# Altium schematic sheet LFI Power Board Sheet1.SchDoc("LFI Power Board Sheet1")
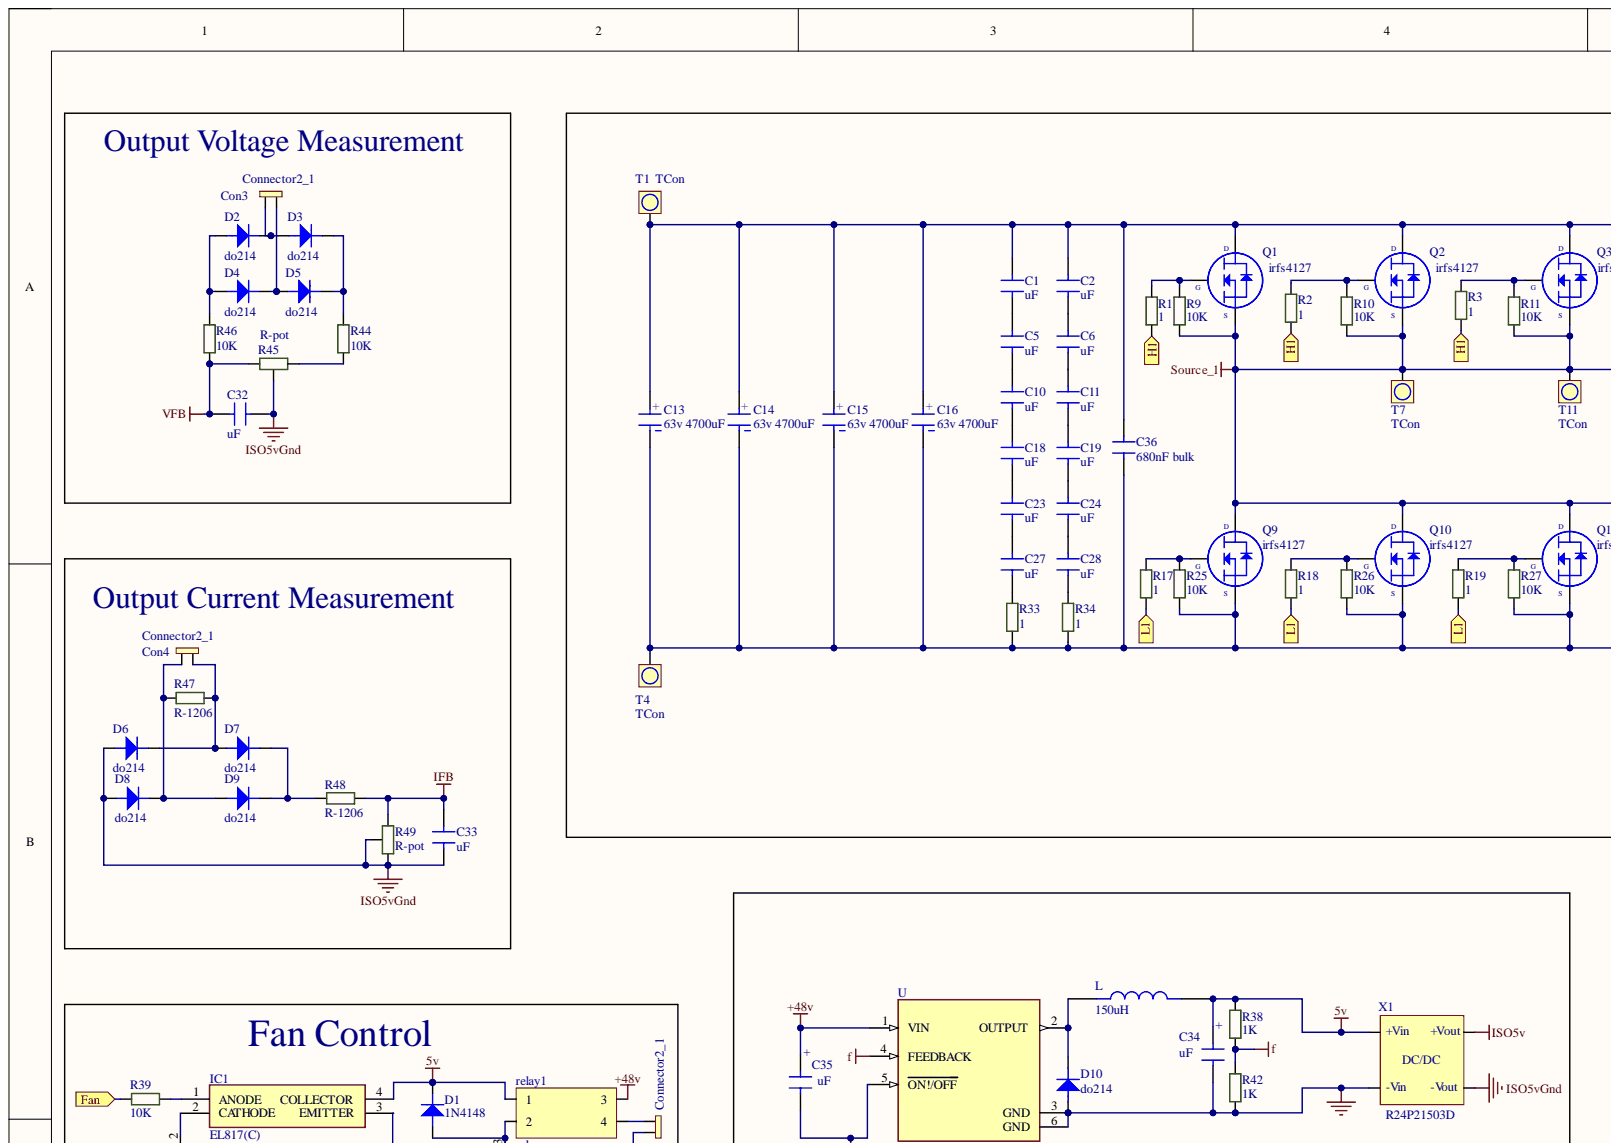
[diagram p0: LFI Power Board Sheet1.SchDoc, top left]
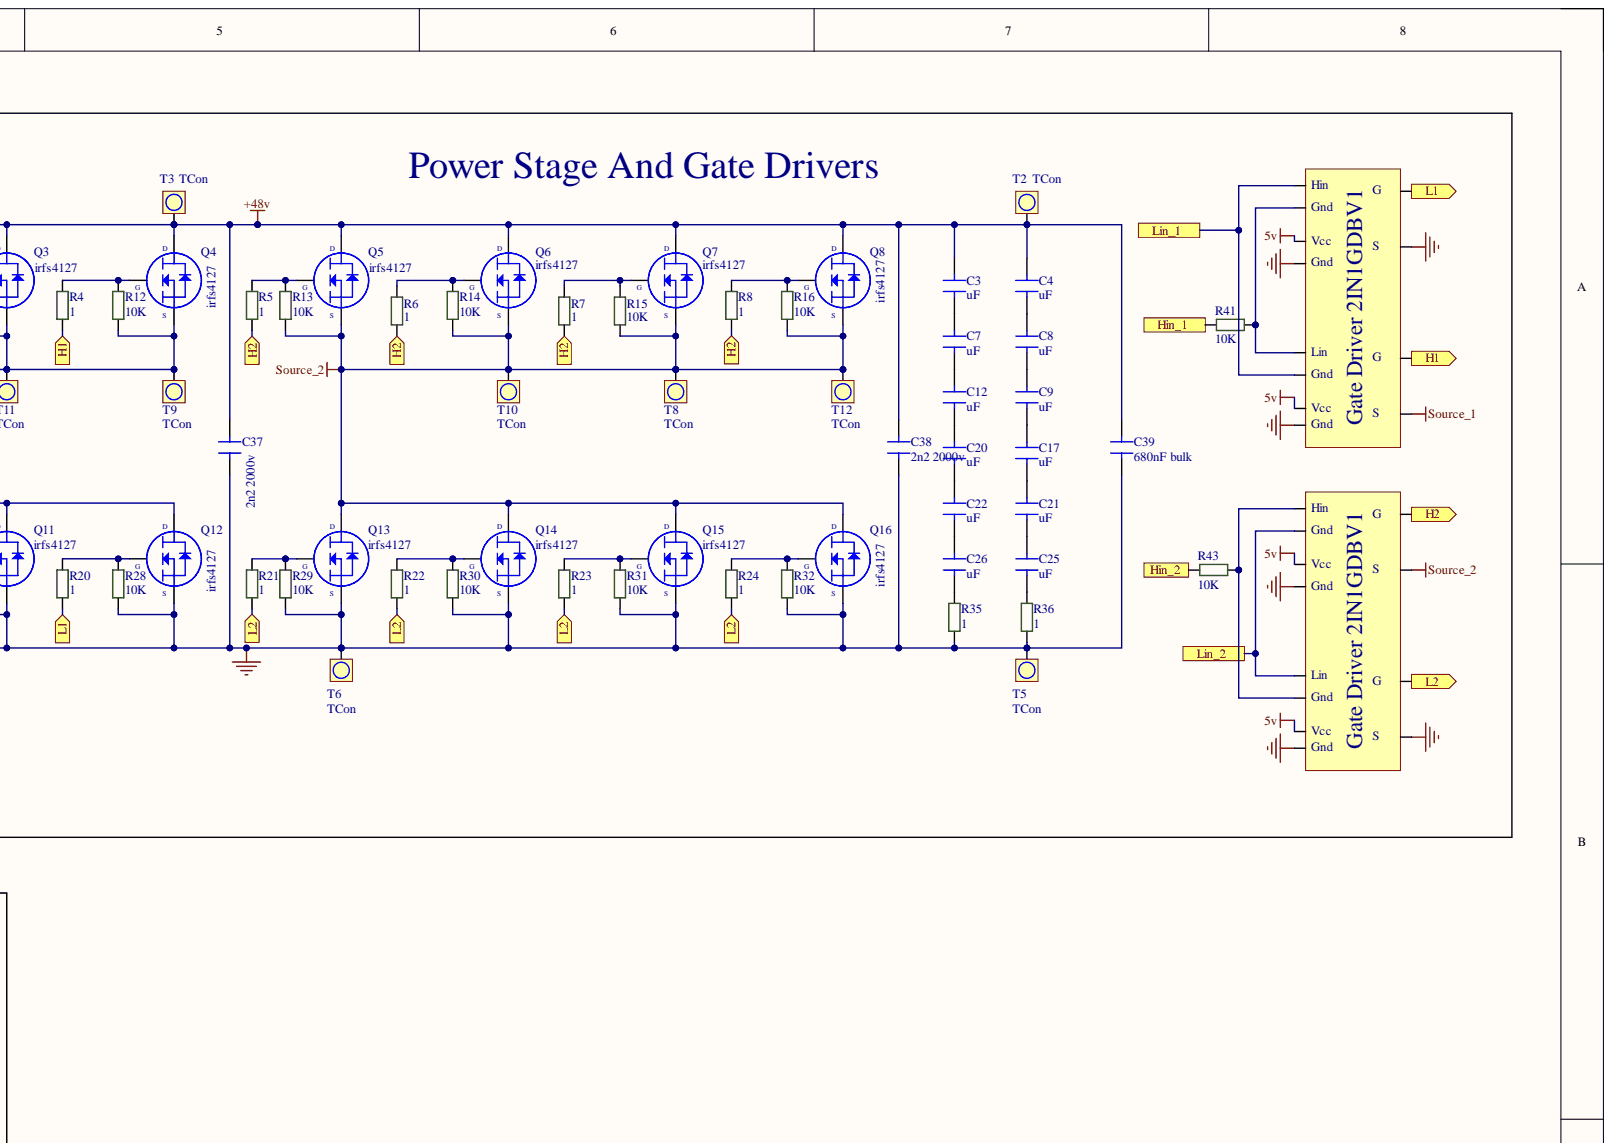
[diagram p1: LFI Power Board Sheet1.SchDoc, top right]
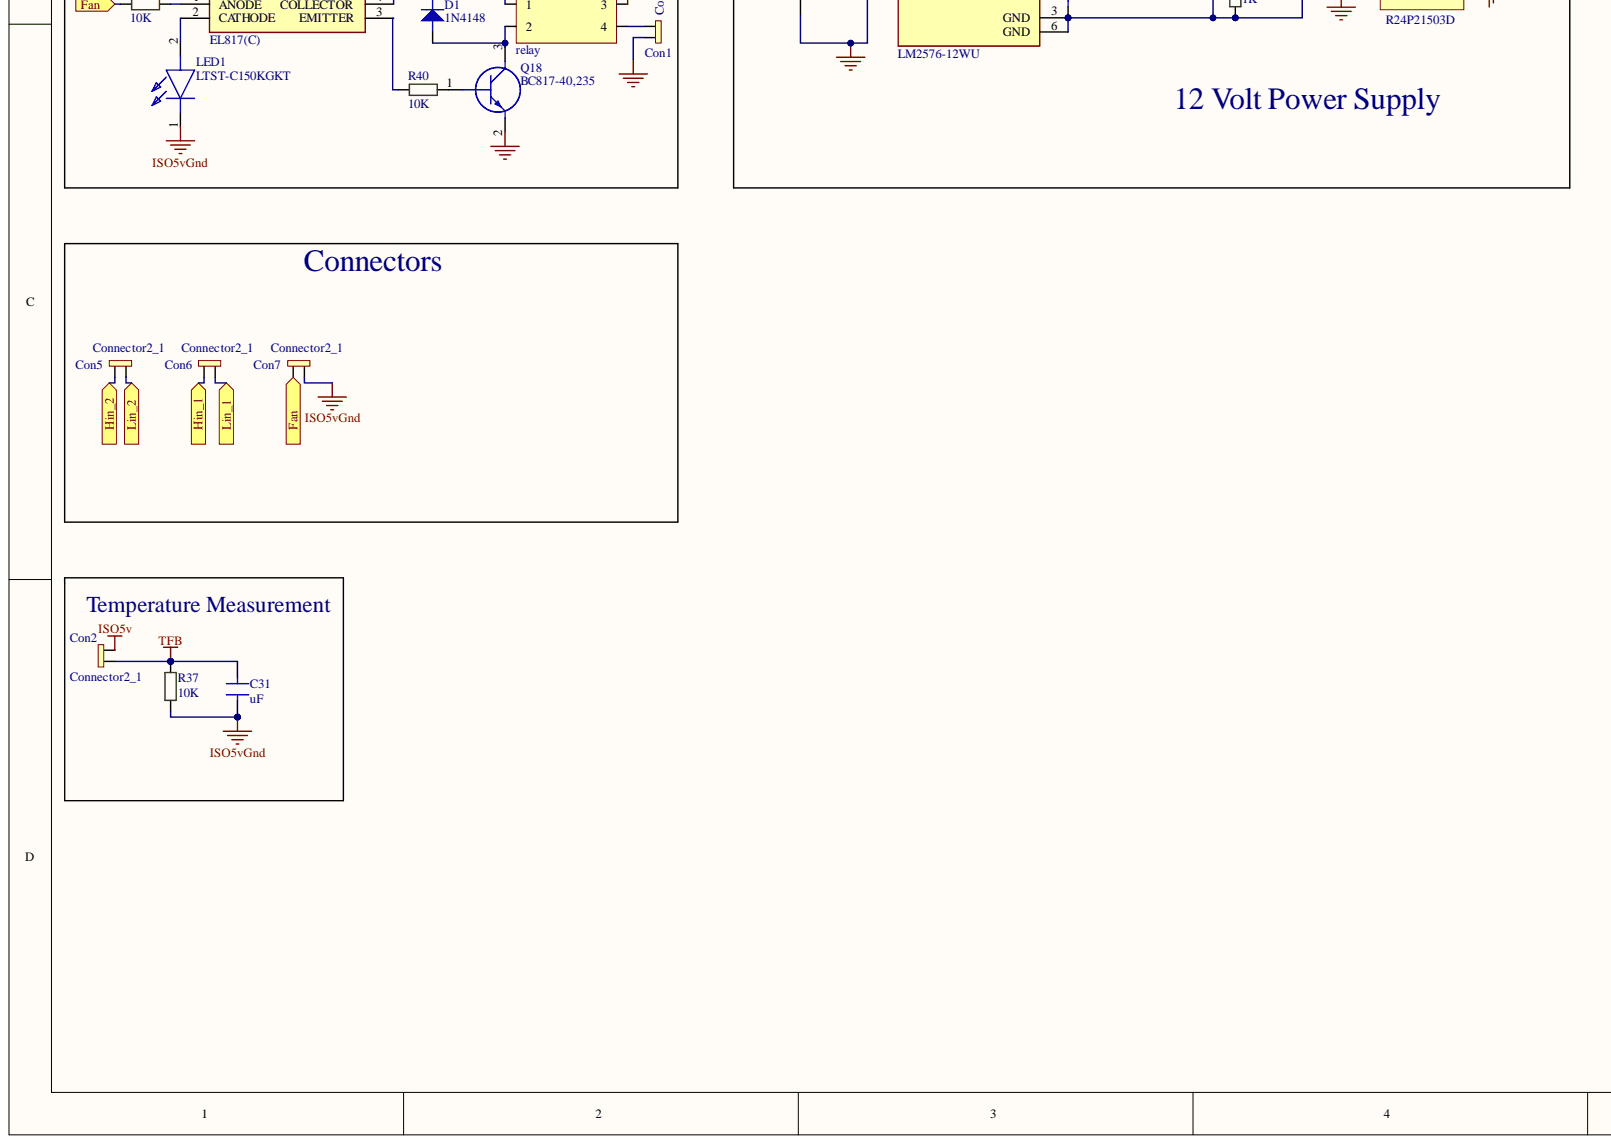
[diagram p2: LFI Power Board Sheet1.SchDoc, bottom left]
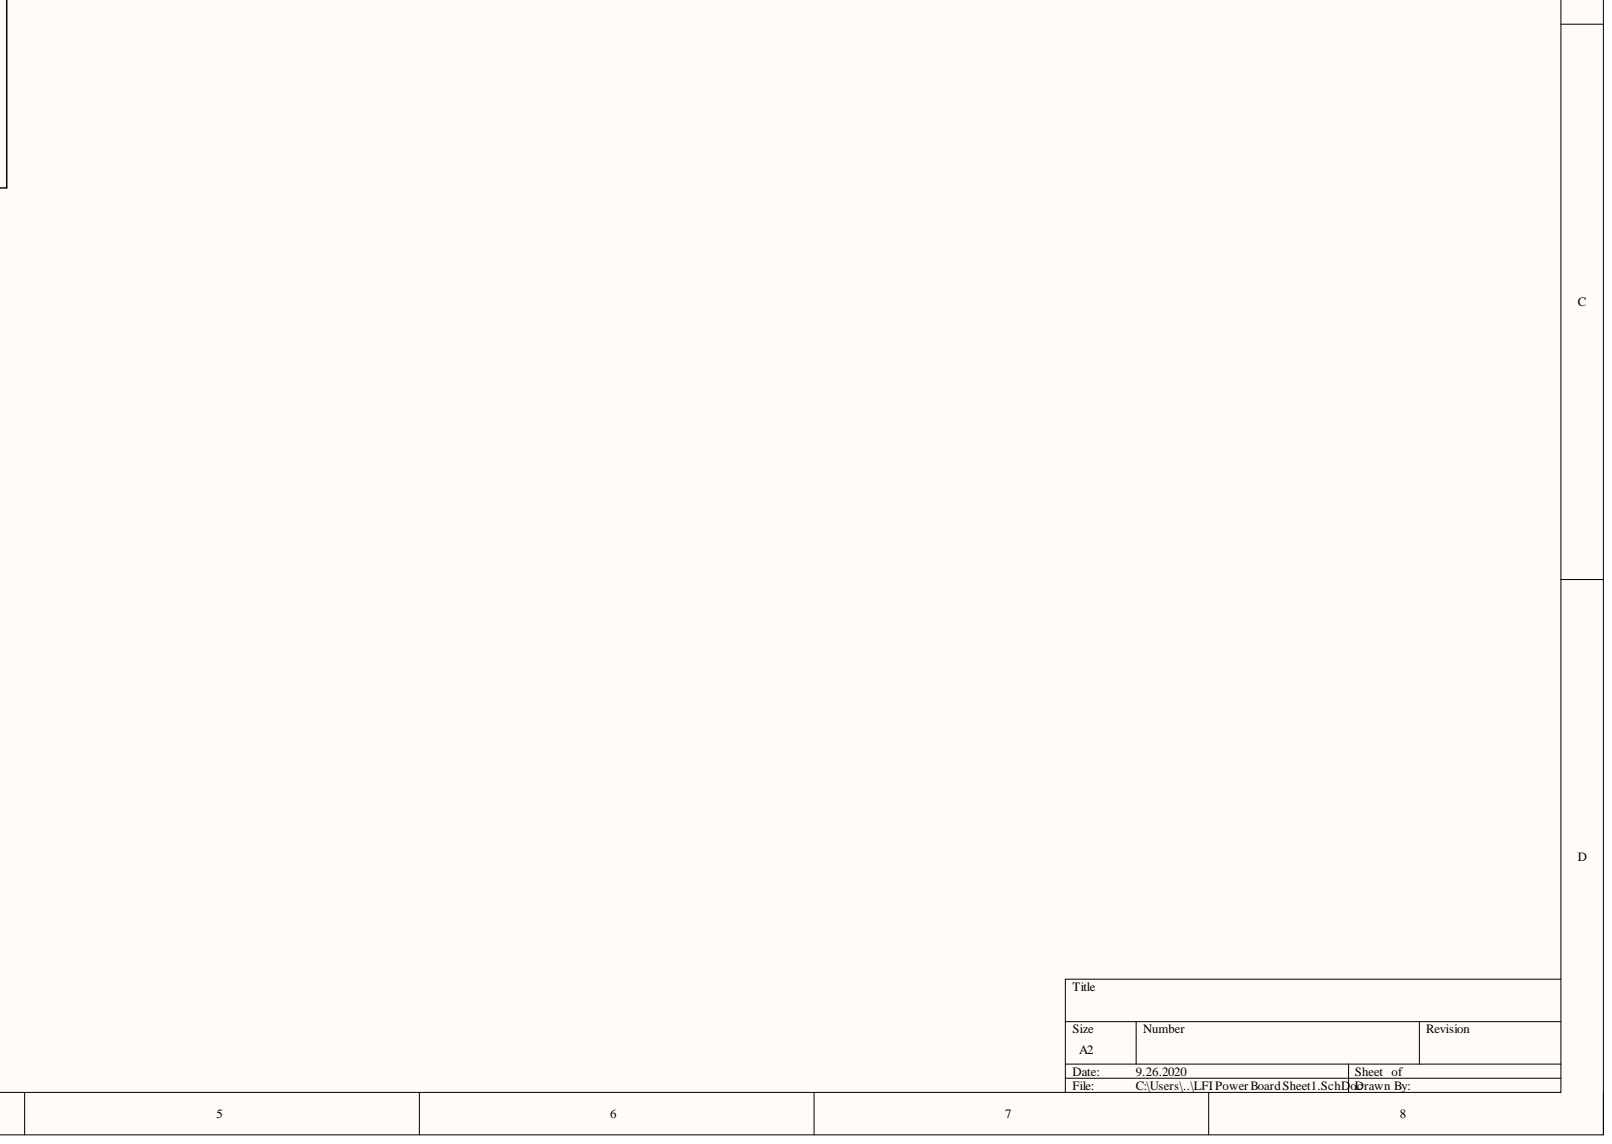
[diagram p3: LFI Power Board Sheet1.SchDoc, bottom right]

## Parts
C1  uF  [C-1206]
C2  uF  [C-1206]
C3  uF  [C-1206]
C4  uF  [C-1206]
C5  uF  [C-1206]
C6  uF  [C-1206]
C7  uF  [C-1206]
C8  uF  [C-1206]
C9  uF  [C-1206]
C10  uF  [C-1206]
C11  uF  [C-1206]
C12  uF  [C-1206]
C13  63v 4700uF  [Koshin 63v 4700 cap]
C14  63v 4700uF  [Koshin 63v 4700 cap]
C15  63v 4700uF  [Koshin 63v 4700 cap]
C16  63v 4700uF  [Koshin 63v 4700 cap]
C17  uF  [C-1206]
C18  uF  [C-1206]
C19  uF  [C-1206]
C20  uF  [C-1206]
C21  uF  [C-1206]
C22  uF  [C-1206]
C23  uF  [C-1206]
C24  uF  [C-1206]
C25  uF  [C-1206]
C26  uF  [C-1206]
C27  uF  [C-1206]
C28  uF  [C-1206]
C31  uF  [C-1206]
C32  uF  [C-1206]
C33  uF  [C-1206]
C34  uF  [CapSmdElc]
C35  uF  [CapSmdElc]
C36  680nF bulk  [680nF bulk]
C37  2n2 2000v  [2n2 2000v]
C38  2n2 2000v  [2n2 2000v]
C39  680nF bulk  [680nF bulk]
Con1  Connector2_1  [2pinCon]
Con2  Connector2_1  [2pinCon]
Con3  Connector2_1  [2pinCon]
Con4  Connector2_1  [2pinCon]
Con5  Connector2_1  [2pinCon]
Con6  Connector2_1  [2pinCon]
Con7  Connector2_1  [2pinCon]
D1  1N4148  [SOD123]
D2  do214  [DO-214AC]
D3  do214  [DO-214AC]
D4  do214  [DO-214AC]
D5  do214  [DO-214AC]
D6  do214  [DO-214AC]
D7  do214  [DO-214AC]
D8  do214  [DO-214AC]
D9  do214  [DO-214AC]
D10  do214  [DO-214AC]
G1  GateDriver Board  [Gate Driver]  (value not drawn)
G2  GateDriver Board  [Gate Driver]  (value not drawn)
IC1  EL817(C)  [DIP762W60P254L458H480Q4N]
L  150uH  [IND_B1047AS-151M=P3]
LED1  LTST-C150KGKT  [L-1206]
Q1  irfs4127  [DPAK_TO263]
Q2  irfs4127  [DPAK_TO263]
Q3  irfs4127  [DPAK_TO263]
Q4  irfs4127  [DPAK_TO263]
Q5  irfs4127  [DPAK_TO263]
Q6  irfs4127  [DPAK_TO263]
Q7  irfs4127  [DPAK_TO263]
Q8  irfs4127  [DPAK_TO263]
Q9  irfs4127  [DPAK_TO263]
Q10  irfs4127  [DPAK_TO263]
Q11  irfs4127  [DPAK_TO263]
Q12  irfs4127  [DPAK_TO263]
Q13  irfs4127  [DPAK_TO263]
Q14  irfs4127  [DPAK_TO263]
Q15  irfs4127  [DPAK_TO263]
Q16  irfs4127  [DPAK_TO263]
Q18  BC817-40,235  [SOT95P230X110-3N]
R1  1  [R-2512W]
R2  1  [R-2512W]
R3  1  [R-2512W]
R4  1  [R-2512W]
R5  1  [R-2512W]
R6  1  [R-2512W]
R7  1  [R-2512W]
R8  1  [R-2512W]
R9  10K  [R-1206]
R10  10K  [R-1206]
R11  10K  [R-1206]
R12  10K  [R-1206]
R13  10K  [R-1206]
R14  10K  [R-1206]
R15  10K  [R-1206]
R16  10K  [R-1206]
R17  1  [R-2512W]
R18  1  [R-2512W]
R19  1  [R-2512W]
R20  1  [R-2512W]
R21  1  [R-2512W]
R22  1  [R-2512W]
R23  1  [R-2512W]
R24  1  [R-2512W]
R25  10K  [R-1206]
R26  10K  [R-1206]
R27  10K  [R-1206]
R28  10K  [R-1206]
R29  10K  [R-1206]
R30  10K  [R-1206]
R31  10K  [R-1206]
R32  10K  [R-1206]
R33  1  [R-2512W]
R34  1  [R-2512W]
R35  1  [R-2512W]
R36  1  [R-2512W]
R37  10K  [R-1206]
R38  1K  [R-1206]
R39  10K  [R-1206]
R40  10K  [R-1206]
R41  10K  [R-1206]
R42  1K  [R-1206]
R43  10K  [R-1206]
R44  10K  [R-1206]
R45  R-pot  [pot]
R46  10K  [R-1206]
R47  R-1206  [R-1206]
R48  R-1206  [R-1206]
R49  R-pot  [pot]
relay1  relay  [Relay]
T1  TCon  [tCon]
T2  TCon  [tCon]
T3  TCon  [tCon]
T4  TCon  [tCon]
T5  TCon  [tCon]
T6  TCon  [tCon]
T7  TCon  [tCon]
T8  TCon  [tCon]
T9  TCon  [tCon]
T10  TCon  [tCon]
T11  TCon  [tCon]
T12  TCon  [tCon]
U  LM2576-12WU  [TO17P1435X465-6N]
X1  R24P21503D  [SIP7]

## Ports
Fan, H1, H2, Hin_1, Hin_2, L1, L2, Lin_1, Lin_2

## Nets
5v: C34.1 D1.k G1.3(Vcc) G1.7(Vcc) G2.3(Vcc) G2.7(Vcc) IC1.4(COLLECTOR) L.2 R38.2 relay1.1 X1.1(+Vin)
+48v: C1.2 C2.2 C3.2 C4.2 C13.2 C14.2 C15.2 C16.2 C35.1 C36.2 C37.2 C38.2 C39.2 Q1.3 Q2.3 Q3.3 Q4.3 Q5.3 Q6.3 Q7.3 Q8.3 relay1.3 T1.1 T2.1 T3.1 U.1(VIN)
f: R38.1 R42.2 U.4(FEEDBACK)
GND: C13.1 C14.1 C15.1 C16.1 C34.2 C35.2 C36.1 C37.1 C38.1 C39.1 Con1.2 D10.a G1.4(Gnd) G1.8(Gnd) G1.10(S) G2.4(Gnd) G2.8(Gnd) G2.12(S) Q9.2 Q10.2 Q11.2 Q12.2 Q13.2 Q14.2 Q15.2 Q16.2 Q18.2 R25.1 R26.1 R27.1 R28.1 R29.1 R30.1 R31.1 R32.1 R33.1 R34.1 R35.1 R36.1 R42.1 T4.1 T5.1 T6.1 U.3(GND) U.5(ON!/OFF) U.6(GND) X1.2(-Vin)
IFB: C33.2 R48.1 R49.1
ISO5v: Con2.2 X1.7(+Vout)
ISO5vGnd: C31.1 C32.2 C33.1 Con7.2 D6.a D8.a LED1.1 R37.1 R45.2 R49.2 R49.3 X1.5(-Vout)
Source_1: G1.12(S) Q1.2 Q2.2 Q3.2 Q4.2 Q9.3 Q10.3 Q11.3 Q12.3 R9.1 R10.1 R11.1 R12.1 T7.1 T9.1 T11.1
Source_2: G2.10(S) Q5.2 Q6.2 Q7.2 Q8.2 Q13.3 Q14.3 Q15.3 Q16.3 R13.1 R14.1 R15.1 R16.1 T8.1 T10.1 T12.1
TFB: C31.2 Con2.1 R37.2
VFB: C32.1 R45.1 R46.1
Fan: Con7.1 R39.2 ; port Fan
H1: G1.11(G) R1.1 R2.1 R3.1 R4.1 ; port H1
H2: G2.9(G) R5.1 R6.1 R7.1 R8.1 ; port H2
Hin_1: Con6.1 R41.2 ; port Hin_1
Hin_2: Con5.1 R43.2 ; port Hin_2
L1: G1.9(G) R17.1 R18.1 R19.1 R20.1 ; port L1
L2: G2.11(G) R21.1 R22.1 R23.1 R24.1 ; port L2
Lin_1: Con6.2 G1.1(Hin) G1.6(Gnd) ; port Lin_1
Lin_2: Con5.2 G2.2(Gnd) G2.5(Lin) ; port Lin_2
NetC1_1: C1.1 C5.2
NetC2_1: C2.1 C6.2
NetC3_1: C3.1 C7.2
NetC4_1: C4.1 C8.2
NetC5_1: C5.1 C10.2
NetC6_1: C6.1 C11.2
NetC7_1: C7.1 C12.2
NetC8_1: C8.1 C9.2
NetC9_1: C9.1 C17.2
NetC10_1: C10.1 C18.2
NetC11_1: C11.1 C19.2
NetC12_1: C12.1 C20.2
NetC17_1: C17.1 C21.2
NetC18_1: C18.1 C23.2
NetC19_1: C19.1 C24.2
NetC20_1: C20.1 C22.2
NetC21_1: C21.1 C25.2
NetC22_1: C22.1 C26.2
NetC23_1: C23.1 C27.2
NetC24_1: C24.1 C28.2
NetC25_1: C25.1 R36.2
NetC26_1: C26.1 R35.2
NetC27_1: C27.1 R33.2
NetC28_1: C28.1 R34.2
NetCon1_1: Con1.1 relay1.4
NetCon3_1: Con3.1 D2.k D3.a
NetCon3_2: Con3.2 D4.k D5.a
NetCon4_1: Con4.1 D8.k D9.a R47.2
NetCon4_2: Con4.2 D6.k D7.a R47.1
NetD1_a: D1.a Q18.3 relay1.2
NetD2_a: D2.a D4.a R46.2
NetD3_k: D3.k D5.k R44.2
NetD7_k: D7.k D9.k R48.2
NetD10_k: D10.k L.1 U.2(OUTPUT)
NetG1_2: G1.2(Gnd) G1.5(Lin) R41.1
NetG2_1: G2.1(Hin) G2.6(Gnd) R43.1
NetLED1_2: IC1.2(CATHODE) LED1.2
NetQ1_1: Q1.1 R1.2 R9.2
NetQ2_1: Q2.1 R2.2 R10.2
NetQ3_1: Q3.1 R3.2 R11.2
NetQ4_1: Q4.1 R4.2 R12.2
NetQ5_1: Q5.1 R5.2 R13.2
NetQ6_1: Q6.1 R6.2 R14.2
NetQ7_1: Q7.1 R7.2 R15.2
NetQ8_1: Q8.1 R8.2 R16.2
NetQ9_1: Q9.1 R17.2 R25.2
NetQ10_1: Q10.1 R18.2 R26.2
NetQ11_1: Q11.1 R19.2 R27.2
NetQ12_1: Q12.1 R20.2 R28.2
NetQ13_1: Q13.1 R21.2 R29.2
NetQ14_1: Q14.1 R22.2 R30.2
NetQ15_1: Q15.1 R23.2 R31.2
NetQ16_1: Q16.1 R24.2 R32.2
NetQ18_1: Q18.1 R40.1
NetR39_1: IC1.1(ANODE) R39.1
NetR40_2: IC1.3(EMITTER) R40.2
NetR44_1: R44.1 R45.3

## Library data of the parts
C1-C12 C17-C28 C31-C33 = C-1206: Component Kind: Standard; Library Name: LFI Power BoardSchlib1.SchLib
C13-C16 = Aluminum Electrolytic Capacitors: PKL5-063V472MM450 Aluminum Electrolytic Capacitors - Snap In 63volts 4700uF 20%; Manufacturer: Nichicon; Manufacturer Part Number: LKS1J472MESZ; Capacitance: 4.7mF; Life (Hours): 1000Hour; Ripple Current: 3A; Case/Package: Radial; Component Kind: Standard; ComponentLink2Description: https://www.ozdisan.com/pasif-komponentler/kondansatorler/aluminyum-kondansatorler/PKL5-063V472MM450; ComponentLink2URL: https://www.ozdisan.com/pasif-komponentler/kondansatorler/aluminyum-kondansatorler/PKL5-063V472MM450; Diameter: 22mm; Height - Seated (Max): 1.772inch; Lead Pitch: 0.394inch; Length: 45mm; Max Operating Temperature: 85°C; Min Operating Temperature: -40°C; Mount: Through Hole; Package Quantity: 250; Packaging: Bulk; RoHS: Yes; Supplier 1: Mouser; Supplier Part Number 1: 647-LKS1J472MESZ; Termination: Snap in; Tolerance: 20%; Voltage Rating: 63V; Voltage Rating (DC): 63V; Library Name: LFI Power BoardSchlib1.SchLib
C34 C35 = C-10uf: Component Kind: Standard; Library Name: LFI Power BoardSchlib1.SchLib
D1 = 1N4148: DIODE FAST SWITCHING Single 150mA 75V SMT SOD323; Component Kind: Standard; Library Name: LFI Power BoardSchlib1.SchLib
D2-D10 = do214: DIODE FAST SWITCHING Single 150mA 75V SMT SOD323; Component Kind: Standard; Library Name: LFI Power BoardSchlib1.SchLib
IC1 = EL817(C): Integrated Circuit; Manufacturer_Name: Everlight; Component Kind: Standard; Datasheet Link: https://componentsearchengine.com/Datasheets/1/EL817(C).pdf; Height: 4.8mm; Manufacturer_Part_Number: EL817(C); Mouser Part Number: 638-EL817C; Mouser Price/Stock: https://www.mouser.com/Search/Refine.aspx?Keyword=638-EL817C; Library Name: LFI Power BoardSchlib1.SchLib
L = 150uH: Inductor with Inductance: 150uH Tol. +/-20%, Package: 2929 _7373_; MF: Murata; MP: B1047AS-151M=P3; Availability: Unavailable; Component Kind: Standard; Package: NONSTANDARD; Price: None; SnapEDA_Link: https://www.snapeda.com/parts/B1047AS-151M%3DP3/Murata/view-part/4548598/?ref=snap; Library Name: LFI Power BoardSchlib1.SchLib
LED1 = LTST-C150KGKT: LED; Manufacturer_Name: Lite-On; Component Kind: Standard; Datasheet Link: http://optoelectronics.liteon.com/upload/download/ds22-2000-072/ltst-c150kgkt.pdf; Height: 1.2mm; Manufacturer_Part_Number: LTST-C150KGKT; Mouser Part Number: 859-LTST-C150KGKT; Mouser Price/Stock: https://www.mouser.com/Search/Refine.aspx?Keyword=859-LTST-C150KGKT; RS Part Number: 6920871; RS Price/Stock: https://uk.rs-online.com/web/p/products/6920871; Library Name: LFI Power BoardSchlib1.SchLib
Q1-Q16 = IRFS4127TRLPBF: MOSFET N-CH 200V 72A D2PAK; Manufacturer: International Rectifier; Drain to Source Resistance: 22mR; Element Configuration: Single; Fall Time: 22ns; Gate to Source Voltage (Vgs): 20V; Max Power Dissipation: 375W; Rise Time: 18ns; Turn-Off Delay Time: 56ns; Turn-On Delay Time: 17ns; Input Capacitance: 5.38nF; Case/Package: D2PAK; Component Kind: Standard; ComponentLink1Description: https://datasheet.ciiva.com/939/irfs4127pbf-939456.pdf?src-supplier=Digi-Key; ComponentLink1URL: https://datasheet.ciiva.com/939/irfs4127pbf-939456.pdf?src-supplier=Digi-Key; ComponentLink2Description: https://datasheet.ciiva.com/939/irfs4127pbf-939457.pdf?src-supplier=Digi-Key; ComponentLink2URL: https://datasheet.ciiva.com/939/irfs4127pbf-939457.pdf?src-supplier=Digi-Key; ComponentLink3Description: https://datasheet.ciiva.com/939/irfs4127pbf-939458.pdf?src-supplier=Digi-Key; ComponentLink3URL: https://datasheet.ciiva.com/939/irfs4127pbf-939458.pdf?src-supplier=Digi-Key; ComponentLink4Description: https://datasheet.ciiva.com/16221/irfs4127pbf-16221031.pdf?src-supplier=Future+Electronics; ComponentLink4URL: https://datasheet.ciiva.com/16221/irfs4127pbf-16221031.pdf?src-supplier=Future+Electronics; Continuous Drain Current (ID): 72A; Drain to Source Breakdown Voltage: 200V; Drain to Source Voltage (Vdss): 200V; Manufacturer Part Number: IRFS4127TRLPBF; Max Operating Temperature: 175°C; Min Operating Temperature: -55°C; Mount: Surface Mount; Number of Pins: 3; On-State Resistance: 22MR; Package Quantity: 1; Packaging: Tape and Reel; Power Dissipation: 375W; Pricing 1: 1 = 3,97000, 10 = 3,54100, 100 = 2,90330 (USD); Rds On Max: 22mR; RoHS: Yes; Stock 1: 15054; Supplier 1: Digi-Key; Supplier Part Number 1: IRFS4127TRLPBFCT-ND; Library Name: LFI Power BoardSchlib1.SchLib
Q18 = BC817-40,235: Transistor BJT NPN; Manufacturer_Name: Nexperia; Component Kind: Standard; Datasheet Link: http://assets.nexperia.com/documents/data-sheet/BC817_BC817W_BC337.pdf; Height: 1.1mm; Manufacturer_Part_Number: BC817-40,235; Mouser Part Number: 771-BC817-40235; Mouser Price/Stock: https://www.mouser.com/Search/Refine.aspx?Keyword=771-BC817-40235; RS Part Number: 1707957; RS Price/Stock: http://uk.rs-online.com/web/p/products/1707957; Library Name: LFI Power BoardSchlib1.SchLib
R1-R8 R17-R24 R33-R36 = 0207 melf: Component Kind: Standard; ComponentLink1Description: https://www.ozdisan.com/pasif-komponentler/direncler/smt-smd-direncler/M271UFG100KT20; ComponentLink1URL: https://www.ozdisan.com/pasif-komponentler/direncler/smt-smd-direncler/M271UFG100KT20; Library Name: LFI Power BoardSchlib1.SchLib
R9-R16 R25-R32 R37-R44 R46 = R-1206_GS: 1206S4F1002T5E; Component Kind: Standard; ComponentLink1Description: link; ComponentLink1URL: https://www.ozdisan.com/pasif-komponentler/direncler/smt-smd-direncler/1206S4F1002T5E; Library Name: LFI Power BoardSchlib1.SchLib
R45 R49 = R-pot: Res1206; Component Kind: Standard; Library Name: LFI Power BoardSchlib1.SchLib
R47 R48 = R-1206: Res1206; Component Kind: Standard; Library Name: LFI Power BoardSchlib1.SchLib
T1-T12 = Terminal Connector: Component Kind: Standard; ComponentLink1Description: https://www.ozdisan.com/konnektor-baglanti-elemanlari/terminal-konnektorler/vida-tipi-konnektorler/K36-00AH; ComponentLink1URL: https://www.ozdisan.com/konnektor-baglanti-elemanlari/terminal-konnektorler/vida-tipi-konnektorler/K36-00AH; Library Name: LFI Power BoardSchlib1.SchLib
U = LM2576-12WU: Buck Switching Regulator IC Positive Fixed 12V 1 Output 3A TO-263-6, DÂ²Pak _5 Leads + Tab_, TO-263BA; MF: Microchip; Availability: Unavailable; Component Kind: Standard; MP: LM2576-12WU; Package: TO-263-5 Microchip; Price: None; SnapEDA_Link: https://www.snapeda.com/parts/LM2576-12WU/Microchip/view-part/2873733/?ref=snap; Library Name: LFI Power BoardSchlib1.SchLib
X1 = R24P21503D: Manufacturer: Recom; Max Output Voltage: 15V; Component Kind: Standard; Height: 12.5mm; Length: 19.5mm; Manufacturer Part Number: R24P21503D; Pricing 1: 1 = 8.78000, 5 = 8.71200, 10 = 8.64500, 25 = 8.51200, 50 = 8.24600, 100 = 7.44800, 250 = 7.31500, 500 = 7.18200 (USD); RoHS: No; Stock 1: 378; Supplier 1: Digi-Key; Supplier Part Number 1: 945-3071-5-ND; Weight: 4.3g; Width: 9.8mm; Library Name: LFI Power BoardSchlib1.SchLib
C36 C39 = 680nF bulk: Component Kind: Standard; Library Name: LFI Power BoardSchlib1.SchLib
C37 C38 = 2n2 2000v: Component Kind: Standard; Library Name: LFI Power BoardSchlib1.SchLib
Con1-Con7 = Connector2_1: Component Kind: Standard; System: Connector; Library Name: LFI Power BoardSchlib1.SchLib
G1 G2 = GateDriver Board: Component Kind: Standard; Library Name: LFI Power BoardSchlib1.SchLib
relay1 = relay: Component Kind: Standard; Library Name: LFI Power BoardSchlib1.SchLib
